# Altium schematic sheet HDMI.SchDoc
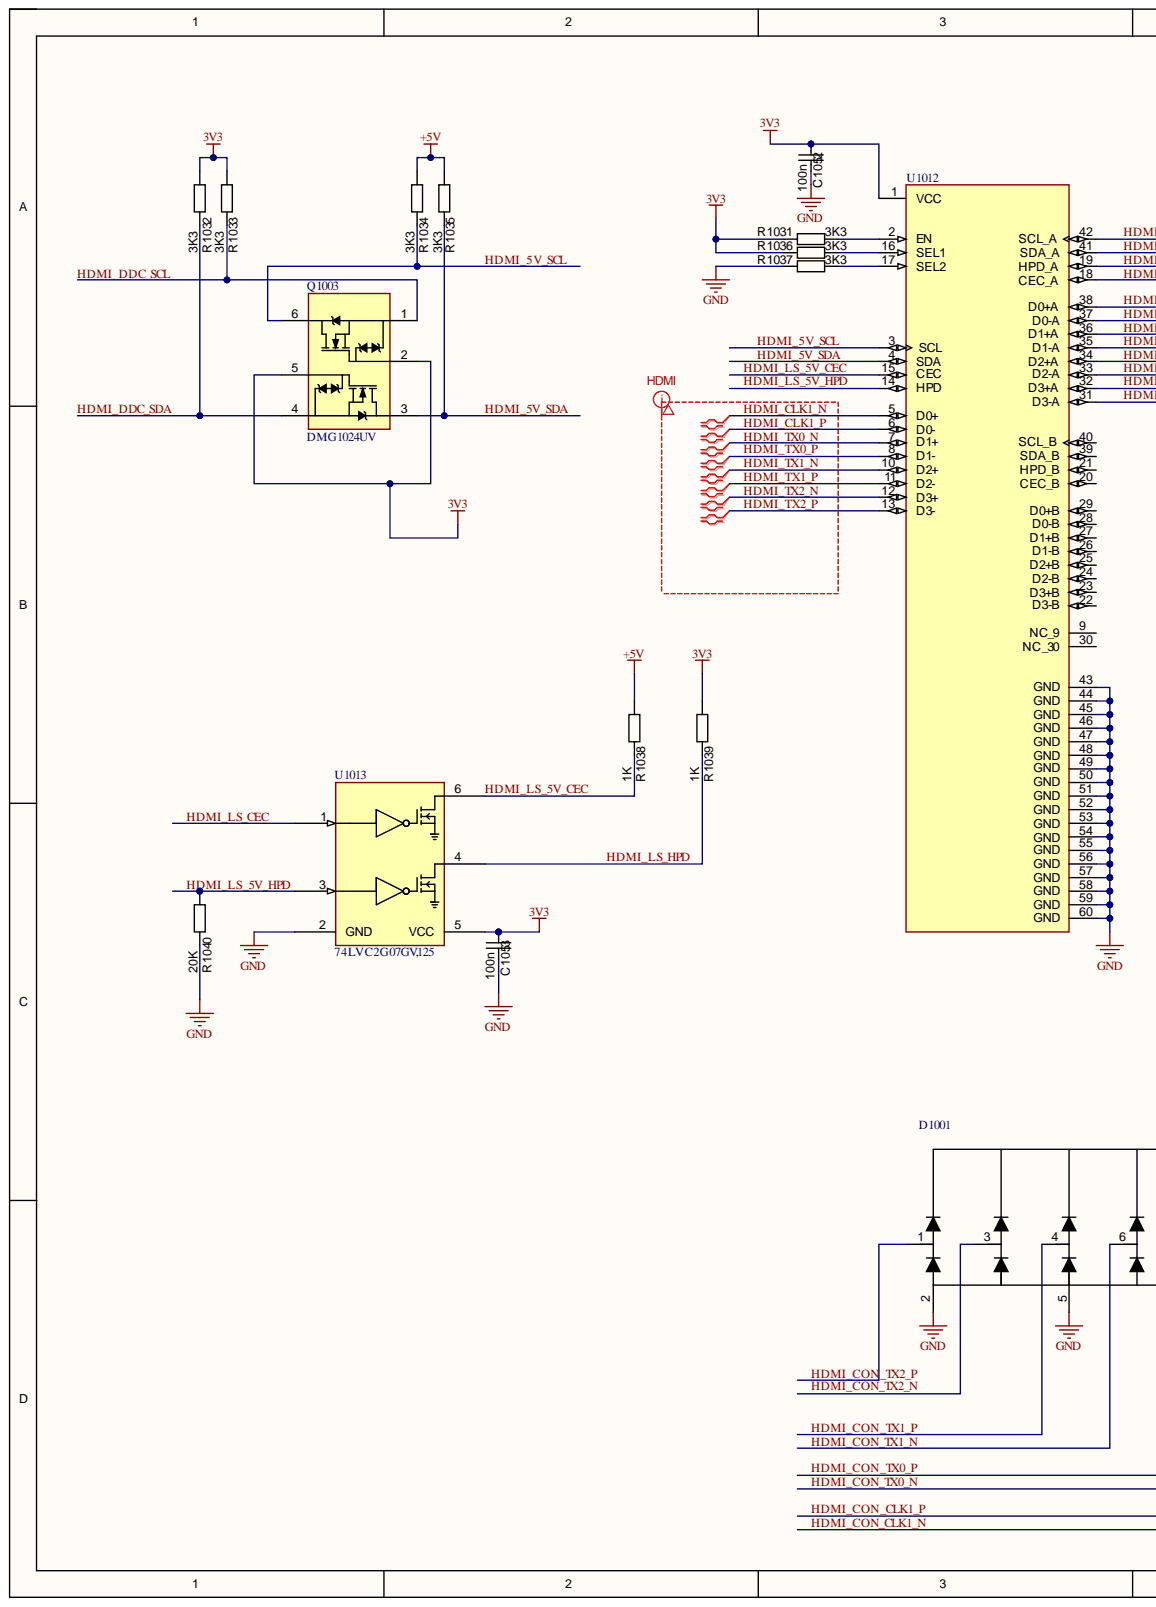
[diagram p0: HDMI.SchDoc, left]
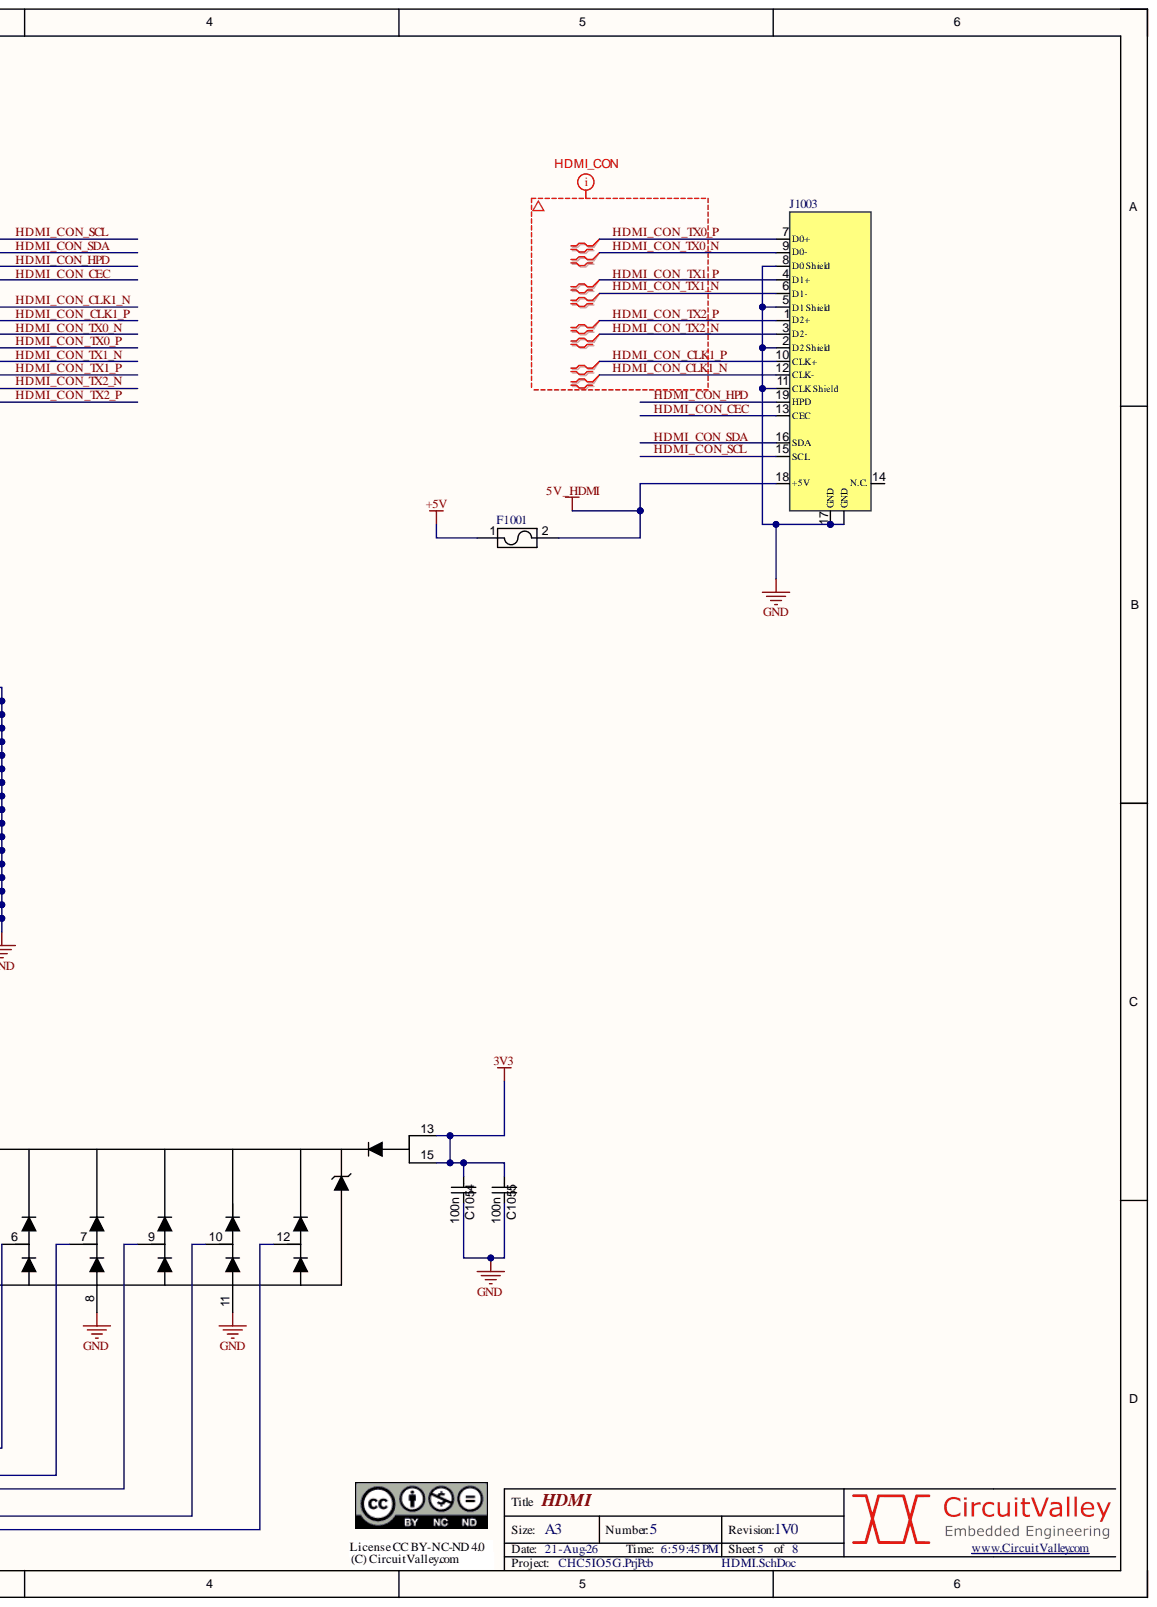
[diagram p1: HDMI.SchDoc, right]

## Parts
C1052  100n  [CAPC1005N]
C1053  100n  [CAPC1005N]
C1054  100n  [CAPC1005N]
C1055  100n  [CAPC1005N]
D1001  TPD8S009DSMR  [WSON-15]  (value not drawn)
F1001  0467.500NRHF  [0467005.NRHF]  (value not drawn)
J1003  10029449-x01  [HYC79-HDMIA19-105]  (value not drawn)
Q1003  DMG1024UV  [SOT563]
R1031  value 3K3  [RESC1005N]  (hidden comment: Thick Film)
R1032  value 3K3  [RESC1005N]  (hidden comment: Thick Film)
R1033  value 3K3  [RESC1005N]  (hidden comment: Thick Film)
R1034  value 3K3  [RESC1005N]  (hidden comment: Thick Film)
R1035  value 3K3  [RESC1005N]  (hidden comment: Thick Film)
R1036  value 3K3  [RESC1005N]  (hidden comment: Thick Film)
R1037  value 3K3  [RESC1005N]  (hidden comment: Thick Film)
R1038  value 1K  [RESC1005N]  (hidden comment: Thick Film)
R1039  value 1K  [RESC1005N]  (hidden comment: Thick Film)
R1040  value 20K  [RESC1005N]  (hidden comment: Thick Film)
U1012  TS3DV642A0RUAR  [QFN50P900X350X80-43N]  (value not drawn)
U1013  74LVC2G07GV,125  [SC74-6]

## Nets
3V3: C1052.2 C1053.2 C1054.2 C1055.2 D1001.13 D1001.15 Q1003.2 Q1003.5 R1031.1 R1032.1 R1033.1 R1036.1 R1039.1 U1012.1(VCC) U1013.5(VCC)
5V_HDMI: F1001.1 F1001.2 J1003.18(+5V) R1034.1 R1035.1 R1038.1
GND: C1052.1 C1053.1 C1054.1 C1055.1 D1001.2 D1001.5 D1001.8 D1001.11 J1003.0(GND) J1003.2(D2_Shield) J1003.5(D1_Shield) J1003.8(D0_Shield) J1003.11(CLK_Shield) J1003.17(GND) R1037.1 R1040.2 U1012.43(GND) U1012.44(GND) U1012.45(GND) U1012.46(GND) U1012.47(GND) U1012.48(GND) U1012.49(GND) U1012.50(GND) U1012.51(GND) U1012.52(GND) U1012.53(GND) U1012.54(GND) U1012.55(GND) U1012.56(GND) U1012.57(GND) U1012.58(GND) U1012.59(GND) U1012.60(GND) U1013.2(GND)
HDMI_5V_SCL: Q1003.6 R1034.2 U1012.3(SCL)
HDMI_5V_SDA: Q1003.3 R1035.2 U1012.4(SDA)
HDMI_CLK1_N: U1012.5(D0+)
HDMI_CLK1_P: U1012.6(D0-)
HDMI_CON_CEC: J1003.13(CEC) U1012.18(CEC_A)
HDMI_CON_CLK1_N: D1001.12 J1003.12(CLK-) U1012.38(D0+A)
HDMI_CON_CLK1_P: D1001.10 J1003.10(CLK+) U1012.37(D0-A)
HDMI_CON_HPD: J1003.19(HPD) U1012.19(HPD_A)
HDMI_CON_SCL: J1003.15(SCL) U1012.42(SCL_A)
HDMI_CON_SDA: J1003.16(SDA) U1012.41(SDA_A)
HDMI_CON_TX0_N: D1001.9 J1003.9(D0-) U1012.36(D1+A)
HDMI_CON_TX0_P: D1001.7 J1003.7(D0+) U1012.35(D1-A)
HDMI_CON_TX1_N: D1001.6 J1003.6(D1-) U1012.34(D2+A)
HDMI_CON_TX1_P: D1001.4 J1003.4(D1+) U1012.33(D2-A)
HDMI_CON_TX2_N: D1001.3 J1003.3(D2-) U1012.32(D3+A)
HDMI_CON_TX2_P: D1001.1 J1003.1(D2+) U1012.31(D3-A)
HDMI_DDC_SCL: Q1003.1 R1033.2
HDMI_DDC_SDA: Q1003.4 R1032.2
HDMI_LS_5V_CEC: R1038.2 U1012.15(CEC) U1013.6
HDMI_LS_5V_HPD: R1040.1 U1012.14(HPD) U1013.3
HDMI_LS_CEC: U1013.1
HDMI_LS_HPD: R1039.2 U1013.4
HDMI_TX0_N: U1012.7(D1+)
HDMI_TX0_P: U1012.8(D1-)
HDMI_TX1_N: U1012.10(D2+)
HDMI_TX1_P: U1012.11(D2-)
HDMI_TX2_N: U1012.12(D3+)
HDMI_TX2_P: U1012.13(D3-)
NetR1031_2: R1031.2 U1012.2(EN)
NetR1036_2: R1036.2 U1012.16(SEL1)
NetR1037_2: R1037.2 U1012.17(SEL2)

Not connected: J1003 14(N.C.); U1012 9(NC_9) 20(CEC_B) 21(HPD_B) 22(D3-B) 23(D3+B) 24(D2-B) 25(D2+B) 26(D1-B) 27(D1+B) 28(D0-B) 29(D0+B) 30(NC_30) 39(SDA_B) 40(SCL_B)

## Library data of the parts
C1052-C1055 = CAPC_10n_0402_16V_10%: Capacitor SMD Cer 10nF 10% 16V X7R 0402; Manufacturer 1: AVX Corporation; Manufacturer Part Number 1: 0402YC103KAT2A; Ratings: 16V; X7R; Component Kind: Standard; ComponentLink1Description: http://www.avx.com/docs/Catalogs/cx7r.pdf; ComponentLink1URL: http://www.avx.com/docs/Catalogs/cx7r.pdf; ComponentLink2Description: http://www.yageo.com/documents/recent/UPY-GPHC_X7R_6.3V-to-50V_8.pdf; ComponentLink2URL: http://www.yageo.com/documents/recent/UPY-GPHC_X7R_6.3V-to-50V_8.pdf; Library Name: PBL - Capacitors.SCHLIB; Manufacturer 2: Yageo; Manufacturer Part Number 2: CC0402KRX7R7BB103; Operating Temperature: -55°C ~ 125°C; Package / Case: 0402 (1005 Metric); Size / Dimension: 0.039" L x 0.020" W (1.00mm x 0.50mm); Supplier 1: Digi-Key; Supplier 2: Digi-Key; Supplier Part Number 1: 478-1114-1-ND; Supplier Part Number 2: 311-1042-1-ND; Tolerance: ±10%; Value: 100n
D1001 = TPD8S009DSMR: Display Port ESD; Component Kind: Standard; Library Name: cvlib.SchLib
F1001 = Fuse: 0467005.NRHF; Component Kind: Standard; Library Name: cvlib.SchLib
J1003 = HDMI Connector: 10029449-x01 HDMI Connector; Component Kind: Standard; Library Name: cvlib.SchLib
R1031-R1040 = RES_3K3_0402_5%: Resistor SMD chip 3.3k Ohm 0.063W 5% 0402; Manufacturer 1: Yageo; Manufacturer Part Number 1: RC0402JR-073K3L; Ratings: 1/16 W; Component Kind: Standard; ComponentLink1Description: http://www.yageo.com/documents/recent/PYu-RC0402_51_RoHS_L_6.pdf; ComponentLink1URL: http://www.yageo.com/documents/recent/PYu-RC0402_51_RoHS_L_6.pdf; Library Name: PBL - Resistors.SCHLIB; Material: Thick Film; Package / Case: 0402 (1005 metric); Supplier 1: Digi-Key; Supplier Part Number 1: 311-3.3KJRCT-ND; Tolerance: ±5%
Q1003 = DMG1024UV: Component Kind: Standard; Description: DMG1024UV; Library Name: cvlib.SchLib
U1012 = TS3DV642A0RUAR: Component Kind: Standard; Description: TS3DV642A0RUAR; Library Name: cvlib.SchLib
U1013 = 74LVC2G07GV,125: Component Kind: Standard; Library Name: cvlib.SchLib
